# Altium schematic sheet 09 CAN&RS485.SchDoc
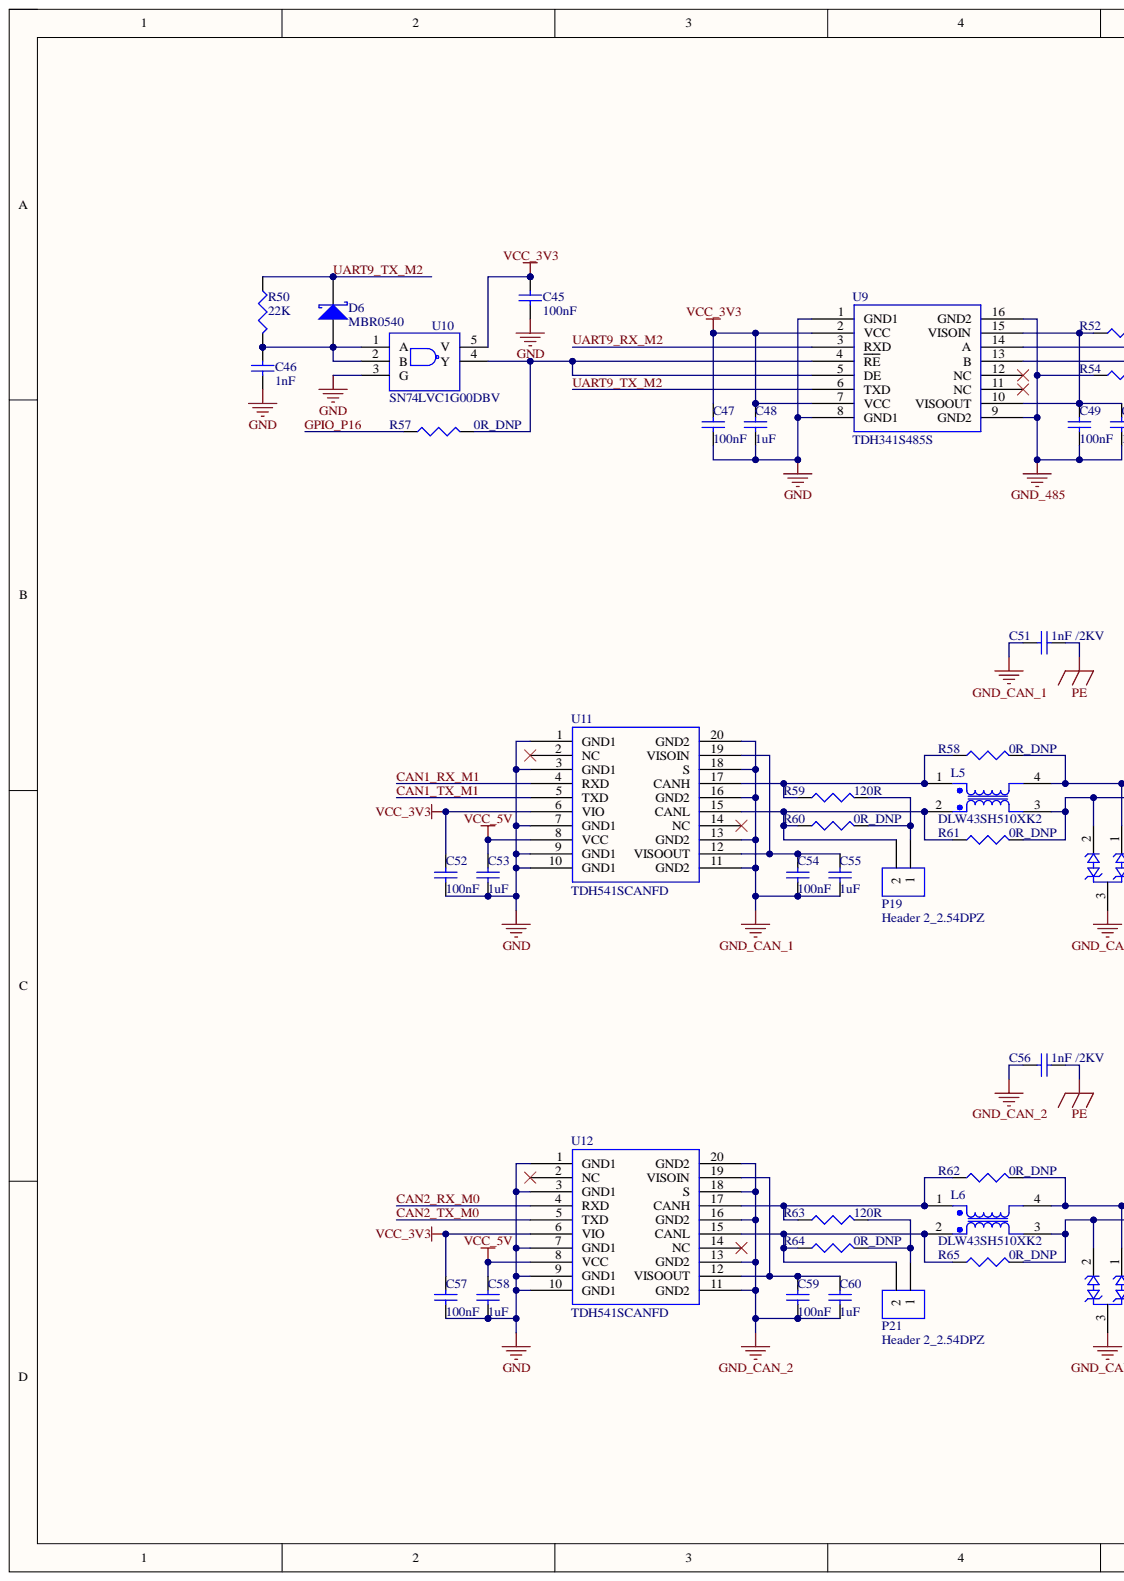
[diagram p0: 09 CAN&RS485.SchDoc, left]
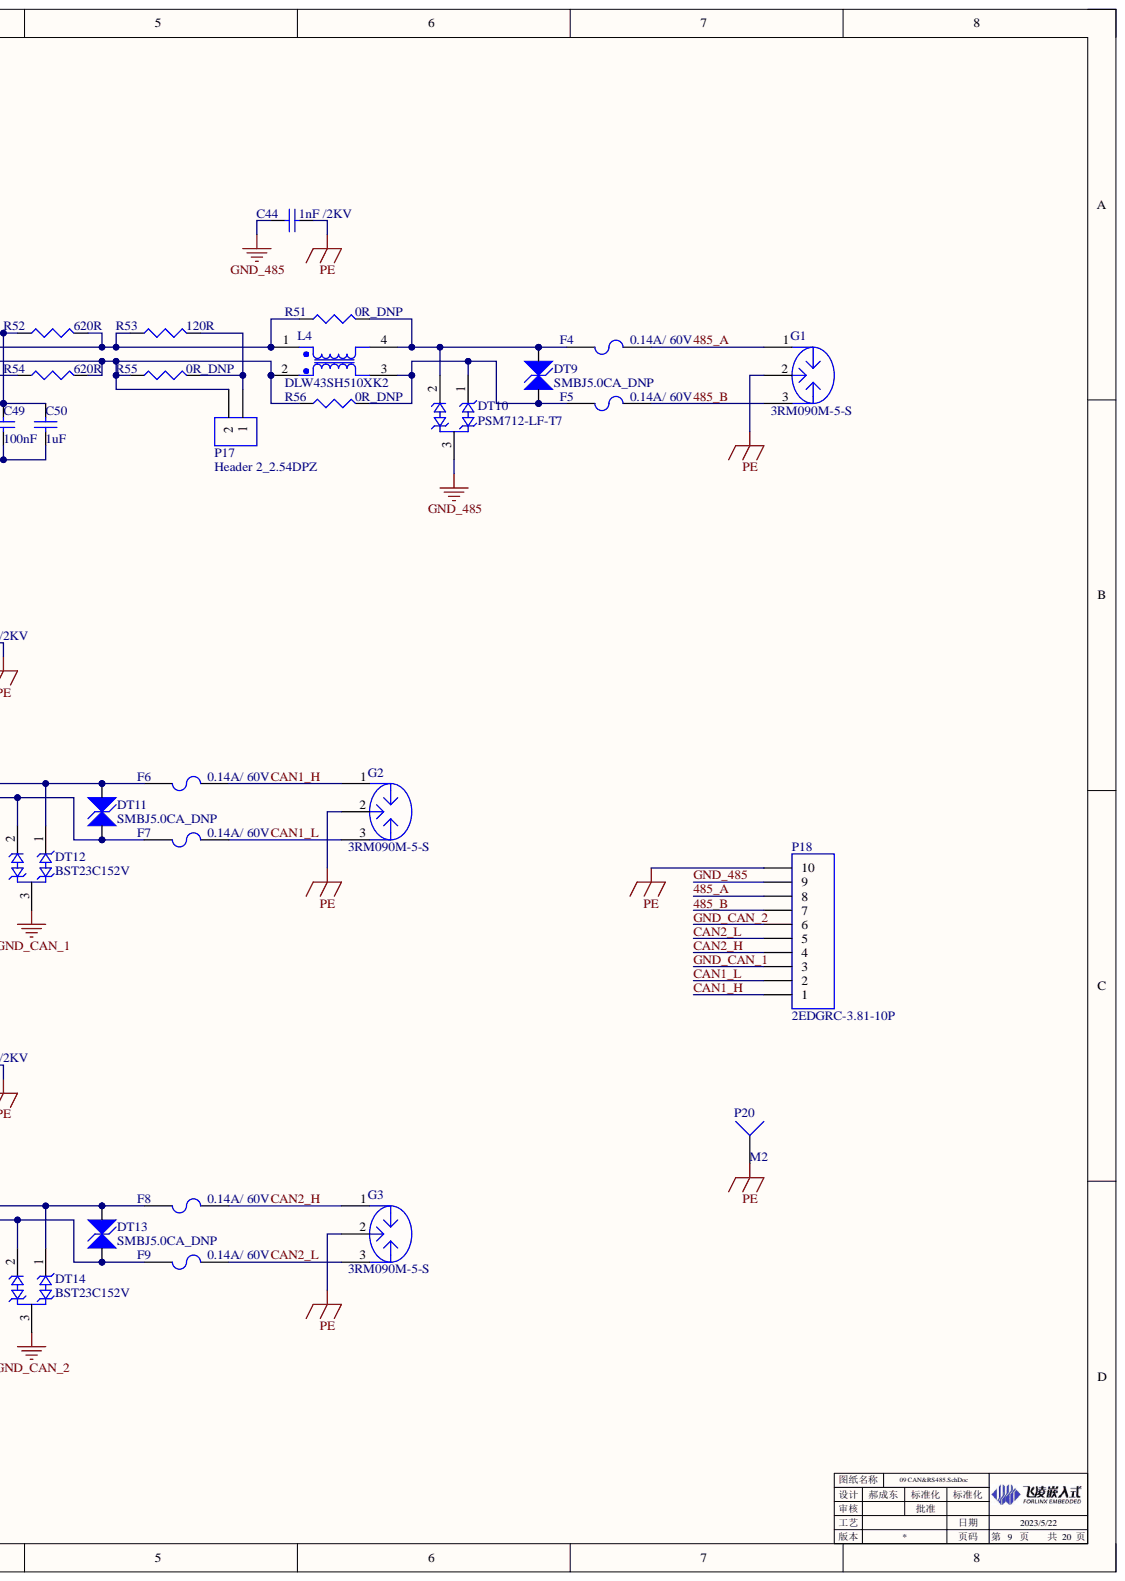
[diagram p1: 09 CAN&RS485.SchDoc, right]

## Parts
C44  1nF /2KV  [1206C]
C45  100nF  [0402C]
C46  1nF  [0402C]
C47  100nF  [0402C]
C48  1uF  [0402C]
C49  100nF  [0402C]
C50  1uF  [0402C]
C51  1nF /2KV  [1206C]
C52  100nF  [0402C]
C53  1uF  [0402C]
C54  100nF  [0402C]
C55  1uF  [0402C]
C56  1nF /2KV  [1206C]
C57  100nF  [0402C]
C58  1uF  [0402C]
C59  100nF  [0402C]
C60  1uF  [0402C]
D6  MBR0540  [SOD_123]
DT9  SMBJ5.0CA_DNP  [SMB/DO-214AA_D]
DT10  PSM712-LF-T7  [SOT_233_N]
DT11  SMBJ5.0CA_DNP  [SMB/DO-214AA_D]
DT12  BST23C152V  [SOT_233_N]
DT13  SMBJ5.0CA_DNP  [SMB/DO-214AA_D]
DT14  BST23C152V  [SOT_233_N]
F4  0.14A/ 60V  [1812F]
F5  0.14A/ 60V  [1812F]
F6  0.14A/ 60V  [1812F]
F7  0.14A/ 60V  [1812F]
F8  0.14A/ 60V  [1812F]
F9  0.14A/ 60V  [1812F]
G1  3RM090M-5-S  [GDT2030]
G2  3RM090M-5-S  [GDT2030]
G3  3RM090M-5-S  [GDT2030]
L4  DLW43SH510XK2  [L_CHK_IND0163_B]
L5  DLW43SH510XK2  [L_CHK_IND0163_B]
L6  DLW43SH510XK2  [L_CHK_IND0163_B]
P17  Header 2_2.54DPZ  [HEADER 2_2.54_DPZ]
P18  2EDGRC-3.81-10P  [HEADER 10_3.81_2EDGR]
P19  Header 2_2.54DPZ  [HEADER 2_2.54_DPZ]
P20  M2  [HOLE3.6-5.6]
P21  Header 2_2.54DPZ  [HEADER 2_2.54_DPZ]
R50  22K  [0402R]
R51  0R_DNP  [0402R]
R52  620R  [0402R]
R53  120R  [0603R]
R54  620R  [0402R]
R55  0R_DNP  [0603R]
R56  0R_DNP  [0402R]
R57  0R_DNP  [0402R]
R58  0R_DNP  [0402R]
R59  120R  [0603R]
R60  0R_DNP  [0603R]
R61  0R_DNP  [0402R]
R62  0R_DNP  [0402R]
R63  120R  [0603R]
R64  0R_DNP  [0603R]
R65  0R_DNP  [0402R]
U9  TDH341S485S  [DFN 16P 10X13mm]
U10  SN74LVC1G00DBV  [SOT_235]
U11  TDH541SCANFD  [DFN 20P 10X13mm]
U12  TDH541SCANFD  [DFN 20P 10X13mm]

## Nets
GND: C45.1 C46.1 C47.1 C48.1 C52.1 C53.1 C57.1 C58.1 U9.1(GND1) U9.8(GND1) U10.3(G) U11.1(GND1) U11.3(GND1) U11.7(GND1) U11.9(GND1) U11.10(GND1) U12.1(GND1) U12.3(GND1) U12.7(GND1) U12.9(GND1) U12.10(GND1)
PE: C44.1 C51.1 C56.1 G1.2 G2.2 G3.2 P18.10 P20.1
VCC_3V3: C45.2 C47.2 C48.2 C52.2 C57.2 U9.2(VCC) U9.7(VCC) U10.5(V) U11.6(VIO) U12.6(VIO)
VCC_5V: C53.2 C58.2 U11.8(VCC) U12.8(VCC)
485_A: F4.1 G1.1 P18.8
485_B: F5.1 G1.3 P18.7
CAN1_H: F6.1 G2.1 P18.1
CAN1_L: F7.1 G2.3 P18.2
CAN1_RX_M1: U11.4(RXD)
CAN1_TX_M1: U11.5(TXD)
CAN2_H: F8.1 G3.1 P18.4
CAN2_L: F9.1 G3.3 P18.5
CAN2_RX_M0: U12.4(RXD)
CAN2_TX_M0: U12.5(TXD)
GND_485: C44.2 C49.1 C50.1 DT10.3 P18.9 R54.1 U9.9(GND2) U9.16(GND2)
GND_CAN_1: C51.2 C54.1 C55.1 DT12.3 P18.3 U11.11(GND2) U11.13(GND2) U11.16(GND2) U11.18(S) U11.20(GND2)
GND_CAN_2: C56.2 C59.1 C60.1 DT14.3 P18.6 U12.11(GND2) U12.13(GND2) U12.16(GND2) U12.18(S) U12.20(GND2)
GPIO_P16: R57.1
UART9_RX_M2: U9.3(RXD)
UART9_TX_M2: D6.2 R50.1 U9.6(TXD)
NetC46_2: C46.2 D6.1 R50.2 U10.1(A) U10.2(B)
NetC49_2: C49.2 C50.2 R52.1 U9.10(VISOOUT) U9.15(VISOIN)
NetC54_2: C54.2 C55.2 U11.12(VISOOUT) U11.19(VISOIN)
NetC59_2: C59.2 C60.2 U12.12(VISOOUT) U12.19(VISOIN)
NetDT9_1: DT9.1 DT10.1 F5.2 L4.3 R56.2
NetDT9_2: DT9.2 DT10.2 F4.2 L4.4 R51.2
NetDT11_1: DT11.1 DT12.2 F7.2 L5.3 R61.2
NetDT11_2: DT11.2 DT12.1 F6.2 L5.4 R58.2
NetDT13_1: DT13.1 DT14.2 F9.2 L6.3 R65.2
NetDT13_2: DT13.2 DT14.1 F8.2 L6.4 R62.2
NetL4_1: L4.1 R51.1 R52.2 R53.1 U9.14(A)
NetL4_2: L4.2 P17.2 R54.2 R55.1 R56.1 U9.13(B)
NetL5_1: L5.1 R58.1 R59.1 U11.17(CANH)
NetL5_2: L5.2 P19.2 R60.1 R61.1 U11.15(CANL)
NetL6_1: L6.1 R62.1 R63.1 U12.17(CANH)
NetL6_2: L6.2 P21.2 R64.1 R65.1 U12.15(CANL)
NetP17_1: P17.1 R53.2 R55.2
NetP19_1: P19.1 R59.2 R60.2
NetP21_1: P21.1 R63.2 R64.2
NetR57_2: R57.2 U9.4(RE) U9.5(DE) U10.4(Y)

Not connected: U9 11(NC) 12(NC); U11 2(NC) 14(NC); U12 2(NC) 14(NC)

## Library data of the parts
C44 C51 C56 = 1110213001: Database Table Name: VALID$; 存货名称: 贴装高压电容; 序号: 770; 规格型号: 1206,1nF,2KV,±10％; Component Kind: Standard; LatestRevisionDate: 17-Jul-2002; LatestRevisionNote: Re-released for DXP Platform.; Library Name: Database_AD Library.DbLib; PackageDescription: Radial Cap, Thru-Hole; 2 Leads; 0.3 in Pin Spacing; PackageReference: RAD-0.3; Published: 8-Jun-2000; Signal Integrity: Cap; Simulation: CAP; Value: 1nF /2KV; 存货编码: 1110213001; Publisher: Altium Limited
C45 C47 C49 C52 C54 C57 C59 = 1110202039: Database Table Name: VALID$; 存货名称: 贴装叠层陶瓷电容; 序号: 626; 规格型号: 0402,100nF,25V,±10％,X5R; Component Kind: Standard; LatestRevisionDate: 17-Jul-2002; LatestRevisionNote: Re-released for DXP Platform.; Library Name: Database_AD Library.DbLib; PackageDescription: Radial Cap, Thru-Hole; 2 Leads; 0.3 in Pin Spacing; PackageReference: RAD-0.3; Published: 8-Jun-2000; Signal Integrity: Cap; Simulation: CAP; Value: 100nF; 存货编码: 1110202039; Publisher: Altium Limited
C46 = 1110202006: Database Table Name: VALID$; 存货名称: 贴装叠层陶瓷电容; 序号: 576; 规格型号: 0402,1nF,50V,±10％,X7R; Component Kind: Standard; LatestRevisionDate: 17-Jul-2002; LatestRevisionNote: Re-released for DXP Platform.; Library Name: Database_AD Library.DbLib; PackageDescription: Radial Cap, Thru-Hole; 2 Leads; 0.3 in Pin Spacing; PackageReference: RAD-0.3; Published: 8-Jun-2000; Signal Integrity: Cap; Simulation: CAP; Value: 1nF; 存货编码: 1110202006; Publisher: Altium Limited
C48 C50 C53 C55 C58 C60 = 1110202021: Database Table Name: VALID$; 存货名称: 贴装叠层陶瓷电容; 序号: 600; 规格型号: 0402,1uF,16V,±10％,X5R; Component Kind: Standard; LatestRevisionDate: 17-Jul-2002; LatestRevisionNote: Re-released for DXP Platform.; Library Name: Database_AD Library.DbLib; PackageDescription: Radial Cap, Thru-Hole; 2 Leads; 0.3 in Pin Spacing; PackageReference: RAD-0.3; Published: 8-Jun-2000; Signal Integrity: Cap; Simulation: CAP; Value: 1uF; 存货编码: 1110202021; Publisher: Altium Limited
D6 = 1110501001: Database Table Name: VALID$; 存货名称: 肖特基二极管; 序号: 2480; 规格型号: MBR0540,SOD123; Code_JEDEC: DO-214-AA; Component Kind: Standard; LatestRevisionDate: 17-Jul-2002; LatestRevisionNote: Re-released for DXP Platform.; Library Name: Database_AD Library.DbLib; PackageDescription: DO-214-AA/SMB; 2 C-Bend Leads; Body 5.3 x 3.6 mm, inc. leads (LxW); PackageReference: SMB; Published: 8-Jun-2000; Signal Integrity: D Schottky; Simulation: SKYDIODE; Value: MBR0540; 存货编码: 1110501001; Publisher: Altium Limited
DT9 DT11 DT13 = 1110503034: Database Table Name: VALID$; 存货名称: TVS管; 序号: 2623; 规格型号: SMBJ5.0CA,SMB(DO-214AA); Component Kind: Standard; Library Name: Database_AD Library.DbLib; Value: SMBJ5.0CA_DNP; 存货编码: 1110503034
DT10 = 1110503019: Database Table Name: VALID$; 存货名称: TVS管; 序号: 2606; 规格型号: PSM712-LF-T7,SOT-23-3; Component Kind: Standard; Library Name: Database_AD Library.DbLib; Value: PSM712-LF-T7; 存货编码: 1110503019
DT12 DT14 = 1110503043: Database Table Name: VALID$; 存货名称: TVS管; 规格型号: BST23C152V,SOT-23-3; Component Kind: Standard; Library Name: Database_AD Library.DbLib; Value: BST23C152V; 存货编码: 1110503043
F4-F9 = 1110305010: Database Table Name: VALID$; 存货名称: 自恢复保险; 序号: 3438; 规格型号: 1812,0.14A/0.34A,60V; Component Kind: Standard; LatestRevisionDate: 17-Jul-2002; LatestRevisionNote: Re-released for DXP Platform.; Library Name: Database_AD Library.DbLib; PackageDescription: Thru-Hole; 2 Leads; PackageReference: PIN-W2/E2.8; Published: 8-Jun-2000; Simulation: FUSE; Value: 0.14A/ 60V; 存货编码: 1110305010; Publisher: Altium Limited
G1-G3 = 1110506004: Database Table Name: VALID$; 存货名称: 气体放电管; 序号: 3343; 规格型号: 3RM090M-5-S(或SS)/SE90-90X,GDT2030; Component Kind: Standard; Library Name: Database_AD Library.DbLib; Value: 3RM090M-5-S; 存货编码: 1110506004
L4-L6 = 1110303001: Database Table Name: VALID$; 存货名称: 贴装共模电感; 序号: 1001; 规格型号: 51uH@100KHz,SMD IND0163-B,800mA,B82793S0513N201; Component Kind: Standard; Library Name: Database_AD Library.DbLib; Value: DLW43SH510XK2; 存货编码: 1110303001
P17 P19 P21 = Header 2_2.54DPZ: Database Table Name: Other$; 存货名称: 插装插针; 存货编码: 1120201017; 安全库存: 260.35; 序号: 39; 旧编码: 010402011; 规格型号: 单排,40P,2.54mm,S,方针; Component Kind: Standard; LatestRevisionDate: 17-Jul-2002; LatestRevisionNote: Re-released for DXP Platform.; Library Name: Database_AD Library.DbLib; Signal Integrity: Connector; Value: Header 2_2.54DPZ; Publisher: Altium Limited
P18 = 1120203066: Database Table Name: VALID$; 存货名称: 接线端子座; 序号: 1643; 规格型号: 2EDG,10P,3.81mm,L,N; Component Kind: Standard; LatestRevisionDate: 17-Jul-2002; LatestRevisionNote: Re-released for DXP Platform.; Library Name: Database_AD Library.DbLib; Signal Integrity: Connector; Value: 2EDGRC-3.81-10P; 存货编码: 1120203066; Publisher: Altium Limited
P20 = 1150303066: Database Table Name: VALID$; 存货名称: 贴片螺母; 规格型号: M2mm,长1.5mm; Component Kind: Standard; LatestRevisionDate: 17-Jul-2002; LatestRevisionNote: Re-released for DXP Platform.; Library Name: Database_AD Library.DbLib; Signal Integrity: Connector; Value: M2; 存货编码: 1150303066; Publisher: Altium Limited
R50 = 1110102092: Database Table Name: VALID$; 存货名称: 贴装电阻; 序号: 126; 规格型号: 0402,22K,±5％; Component Kind: Standard; Library Name: Database_AD Library.DbLib; Signal Integrity: Res; Simulation: RESISTOR; Value: 22K; 存货编码: 1110102092
R51 R56-R58 R61 R62 R65 = 1110102001: Database Table Name: VALID$; 存货名称: 贴装电阻; 序号: 10; 规格型号: 0402,0Ω,±5％; Component Kind: Standard; Library Name: Database_AD Library.DbLib; Signal Integrity: Res; Simulation: RESISTOR; Value: 0R_DNP; 存货编码: 1110102001
R52 R54 = 1110102158: Database Table Name: VALID$; 存货名称: 贴装电阻; 规格型号: 0402,620Ω,±1％; Component Kind: Standard; Library Name: Database_AD Library.DbLib; Signal Integrity: Res; Simulation: RESISTOR; Value: 620R; 存货编码: 1110102158
R53 R59 R63 = 1110103021: Database Table Name: VALID$; 存货名称: 贴装电阻; 序号: 192; 规格型号: 0603,120Ω,±5％; Component Kind: Standard; Library Name: Database_AD Library.DbLib; Signal Integrity: Res; Simulation: RESISTOR; Value: 120R; 存货编码: 1110103021
R55 R60 R64 = 1110103004: Database Table Name: VALID$; 存货名称: 贴装电阻; 序号: 172; 规格型号: 0603,0Ω,±5％; Component Kind: Standard; Library Name: Database_AD Library.DbLib; Signal Integrity: Res; Simulation: RESISTOR; Value: 0R_DNP; 存货编码: 1110103004
U10 = 1110405024: Database Table Name: VALID$; 存货名称: 常规IC; 序号: 1899; 规格型号: SN74LVC1G00DBV,SOT23-5; Component Kind: Standard; Library Name: Database_AD Library.DbLib; Value: SN74LVC1G00DBV; 存货编码: 1110405024
U9 = TDH341S485S: Component Kind: Standard; Library Name: 100P连接器.SchLib
U11 U12 = TDH541SCANFD: Component Kind: Standard; Library Name: 100P连接器.SchLib
